# Altium schematic sheet CUBETTO ALM.SchDoc("CUBETTO ALM")
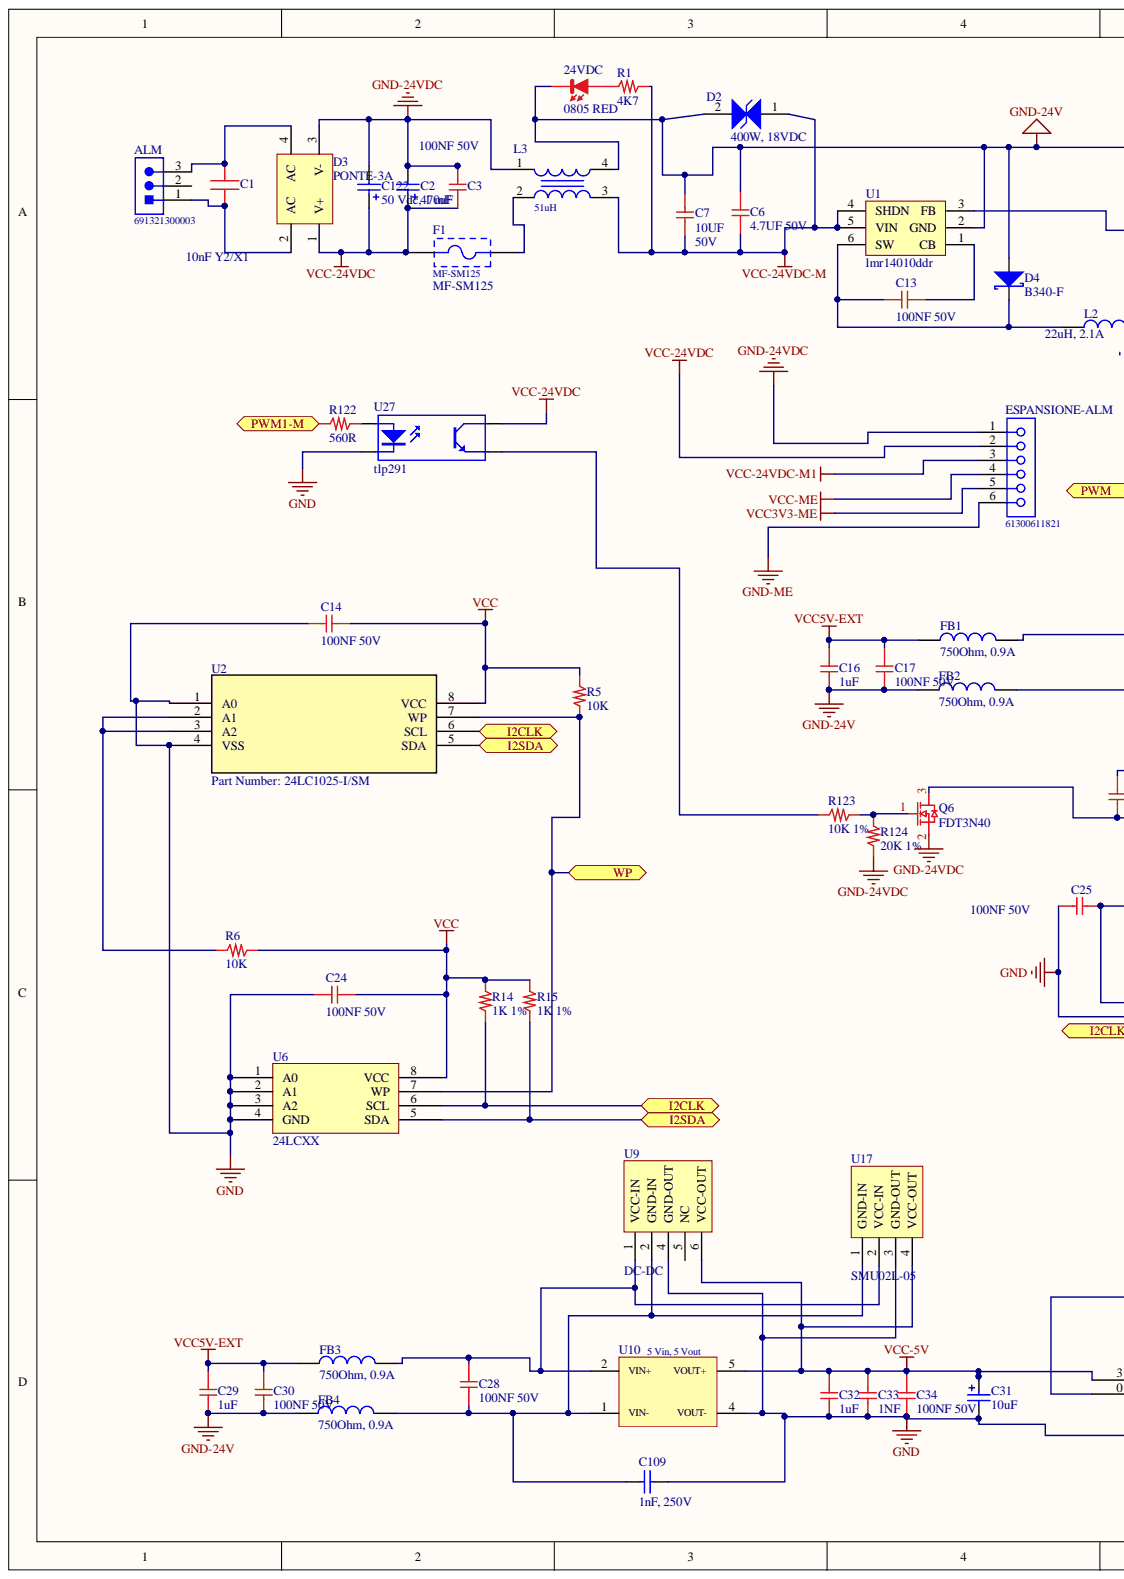
[diagram p0: CUBETTO ALM.SchDoc, left]
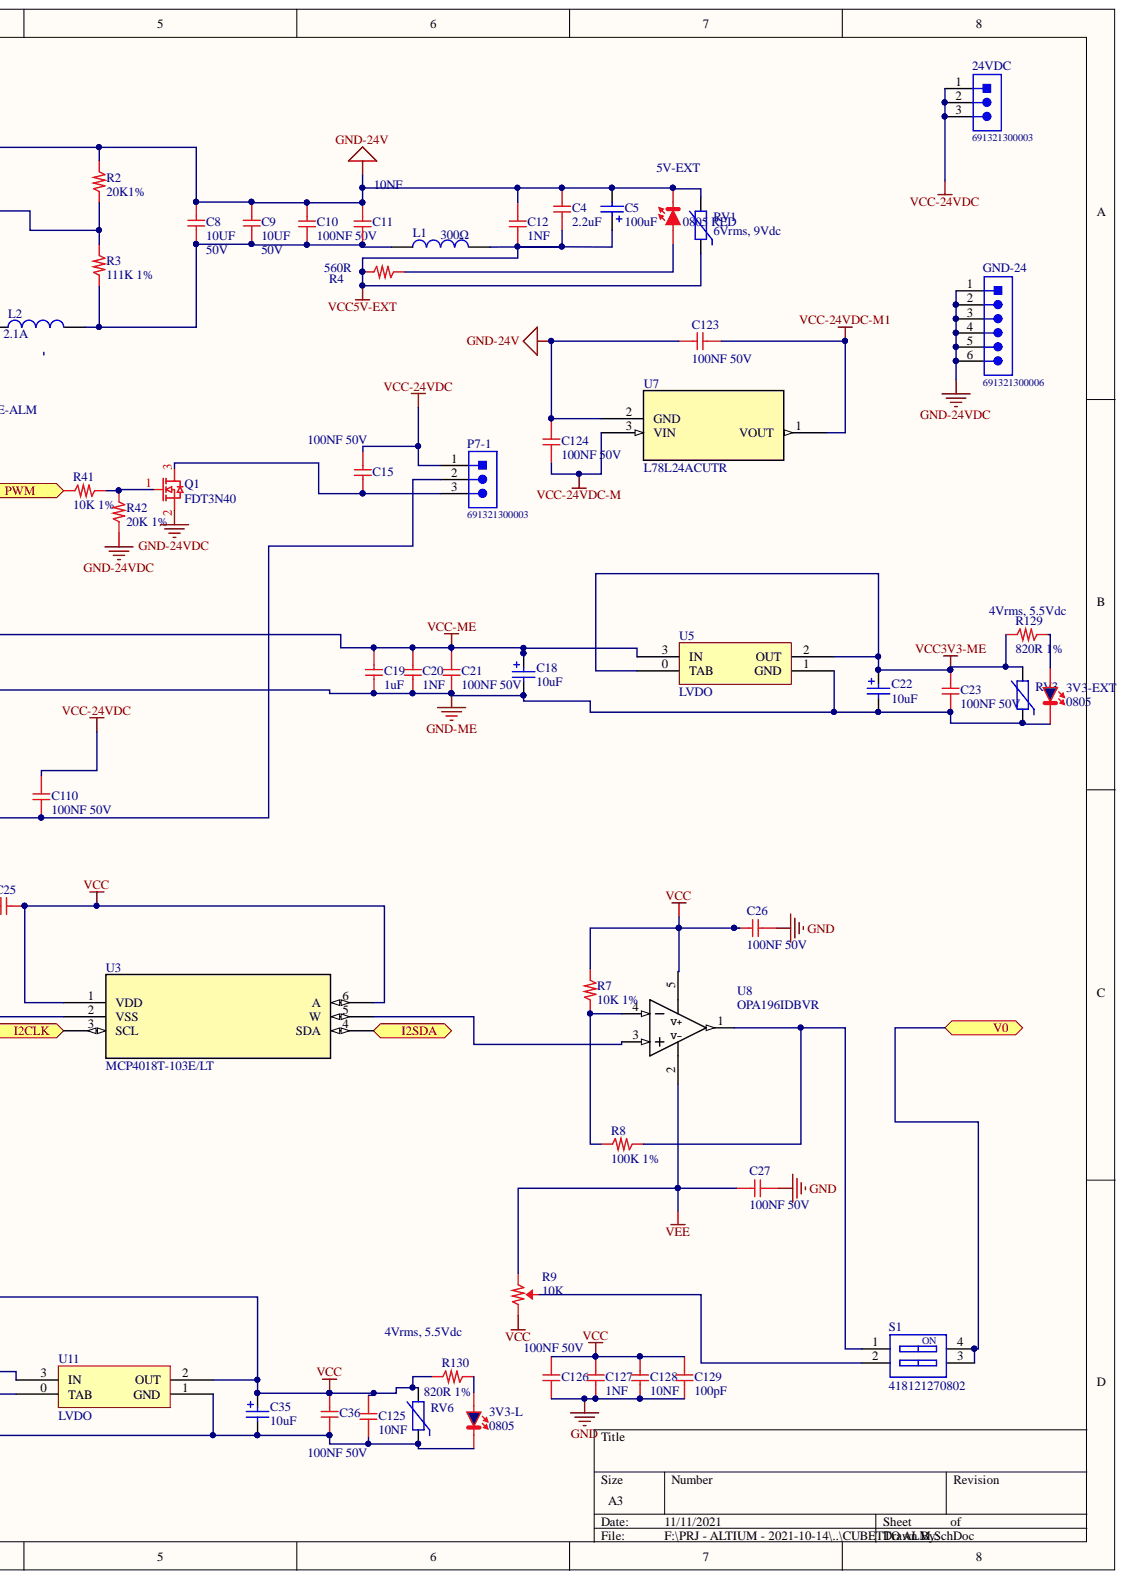
[diagram p1: CUBETTO ALM.SchDoc, right]

## Parts
3V3-EXT  0805  [0805-BLU]
3V3-L  0805  [0805-BLU]
5V-EXT  0805 RED  [0805_Red]
24VDC  0805 RED  [0805_Red]
24VDC  691321300003  [691321300003]
ALM  691321300003  [691321300003]
C1  10nF Y2/X1  [2220_C]
C2  value 470uF  [WCAP-ASLL_16x17]  (hidden comment: 865060663013)
C3  100NF 50V  [0603_c]
C4  2.2uF  [0603_c]
C5  value 100uF  [WCAP-ASLL_6.3x5.5]  (hidden comment: 865060143004)
C6  4.7UF 50V  [0805_C]
C7  10UF  Tensione: 50V  [1206_C]
C8  10UF  Tensione: 50V  [1206_C]
C9  10UF  Tensione: 50V  [1206_C]
C10  100NF 50V  [0603_c]
C11  10NF  [0603_c]
C12  1NF  [0603_c]
C13  100NF 50V  [0603_c]
C14  100NF 50V  [0603_c]
C15  100NF 50V  [0603_c]
C16  1uF  [0603_c]
C17  100NF 50V  [0603_c]
C18  value 10uF  [WCAP-ASLL_4x5.5]  (hidden comment: 865060340001)
C19  1uF  [0603_c]
C20  1NF  [0603_c]
C21  100NF 50V  [0603_c]
C22  value 10uF  [WCAP-ASLL_4x5.5]  (hidden comment: 865060340001)
C23  100NF 50V  [0603_c]
C24  100NF 50V  [0603_c]
C25  100NF 50V  [0603_c]
C26  100NF 50V  [0603_c]
C27  100NF 50V  [0603_c]
C28  100NF 50V  [0603_c]
C29  1uF  [0603_c]
C30  100NF 50V  [0603_c]
C31  value 10uF  [WCAP-ASLL_4x5.5]  (hidden comment: 865060340001)
C32  1uF  [0603_c]
C33  1NF  [0603_c]
C34  100NF 50V  [0603_c]
C35  value 10uF  [WCAP-ASLL_4x5.5]  (hidden comment: 865060340001)
C36  100NF 50V  [0603_c]
C109  1nF, 250V  [WCAP-CSSA_1808_H=2mm]
C110  100NF 50V  [0603_c]
C122  50 Vdc, 1 mF  value 1 mF  [WCAP-ATLI_16x25x7.5]
C123  100NF 50V  [0603_c]
C124  100NF 50V  [0603_c]
C125  10NF  [0603_c]
C126  100NF 50V  [0603_c]
C127  1NF  [0603_c]
C128  10NF  [0603_c]
C129  100pF  [0603_c]
D2  400W, 18VDC  [WE-TVSP_DO-214AC_Bidirectional]
D3  PONTE-3A  [RDBF-310]
D4  B340-F  [SMA]
ESPANSIONE-ALM  61300611821  [61300611821]
F1  MF-SM125  [FUSESMD]
FB1  750Ohm, 0.9A  Rated Current: 0.9A  [WE-CBF_0603_Wide Band]
FB2  750Ohm, 0.9A  Rated Current: 0.9A  [WE-CBF_0603_Wide Band]
FB3  750Ohm, 0.9A  Rated Current: 0.9A  [WE-CBF_0603_Wide Band]
FB4  750Ohm, 0.9A  Rated Current: 0.9A  [WE-CBF_0603_Wide Band]
GND-24  691321300006  [691321300006]
L1  300Ω  [WE-CBF_0805_High Current]
L2  22uH, 2.1A  Rated Current: 2.1A  [WE-LQS_8040]
L3  51uH  [WE-SL2]
P7-1  691321300003  [691321300003]
Q1  FDT3N40  [FDT3N40]
Q6  FDT3N40  [FDT3N40]
R1  4K7  [1206_R]
R2  20K1%  [0603_R]
R3  111K 1%  [0603_R]
R4  560R  [0603_R]
R5  10K  [0603_R]
R6  10K  [0603_R]
R7  10K 1%  [0603_R]
R8  100K 1%  [0603_R]
R9  10K  [ResTrimSuntan5X10X10]
R14  1K 1%  [0603_R]
R15  1K 1%  [0603_R]
R41  10K 1%  [0603_R]
R42  20K 1%  [0603_R]
R122  560R  [0603_R]
R123  10K 1%  [0603_R]
R124  20K 1%  [0603_R]
R129  820R 1%  [0603_R]
R130  820R 1%  [0603_R]
RV1  6Vrms, 9Vdc  Size: 0805  [WE-VS_0805]
RV3  4Vrms, 5.5Vdc  [WE-VS_0603]
RV6  4Vrms, 5.5Vdc  [WE-VS_0603]
S1  418121270802  [418121270802]
U1  lmr14010ddr  [SOT23-6_N]
U2  Part Number: 24LC1025-I/SM  [SOIJ8-M_MC]
U3  MCP4018T-103E/LT  [SOT363]
U5  LVDO  [SOT-223]
U6  24LCXX  [SO8_N]
U7  L78L24ACUTR  [SOT-89-3]
U8  OPA196IDBVR  [DBV0005A_N]
U9  DC-DC  [DC-DC-DL 2W]
U10  5 Vin, 5 Vout  [MagI³C-FISM_SMT-8]
U11  LVDO  [SOT-223]
U17  SMU02L-05  [DC.DC-2W]
U27  tlp291  [SO4_N]

## Ports
I2CLK, I2SDA, PWM, PWM1-M, V0, WP

## Nets
GND: 3V3-L.K C14.1 C24.1 C25.1 C26.1 C27.1 C31.2 C32.2 C33.2 C34.2 C35.2 C36.2 C109.2 C125.2 C126.2 C127.2 C128.2 C129.2 RV6.1 U2.1(A0) U2.4(VSS) U3.2(VSS) U6.1(A0) U6.2(A1) U6.3(A2) U6.4(GND) U9.4(GND-OUT) U10.4(VOUT-) U11.1(GND) U17.3(GND-OUT) U27.2
GND-24V: 5V-EXT.K 24VDC.K C4.1 C5.2 C6.2 C7.1 C8.2 C9.2 C10.2 C11.2 C12.1 C16.2 C17.2 C29.2 C30.2 C123.2 C124.2 D2.2 D4.1 FB2.1 FB4.1 L3.4 R2.1 RV1.2 U1.2(GND) U7.2
GND-24VDC: C2.2 C3.2 C122.2 D3.3(V-) ESPANSIONE-ALM.1 GND-24.1 GND-24.2 GND-24.3 GND-24.4 GND-24.5 GND-24.6 L3.1 Q1.2 Q6.2 R42.2 R124.2
GND-ME: 3V3-EXT.K C18.2 C19.2 C20.2 C21.2 C22.2 C23.2 ESPANSIONE-ALM.6 FB2.2 RV3.1 U5.1(GND)
VCC: C14.2 C24.2 C25.2 C26.2 C35.1 C36.1 C125.1 C126.1 C127.1 C128.1 C129.1 R5.1 R6.2 R7.1 R9.3 R14.2 R15.1 R130.1 RV6.2 U2.8(VCC) U3.1(VDD) U3.6(A) U6.8(VCC) U8.5(V+) U11.0(TAB) U11.2(OUT)
VCC3V3-ME: C22.1 C23.1 ESPANSIONE-ALM.5 R129.1 RV3.2 U5.0(TAB) U5.2(OUT)
VCC5V-EXT: C4.2 C5.1 C12.2 C16.1 C17.1 C29.1 C30.1 FB1.1 FB3.1 L1.2 R4.1 RV1.1
VCC-5V: C31.1 C32.1 C33.1 C34.1 U9.6(VCC-OUT) U10.5(VOUT+) U11.3(IN) U17.4(VCC-OUT)
VCC-24VDC: 24VDC.1 24VDC.2 24VDC.3 C2.1 C3.1 C15.2 C110.2 C122.1 D3.1(V+) ESPANSIONE-ALM.2 F1.2 P7-1.1 U27.4
VCC-24VDC-M: C6.1 C7.2 C124.1 D2.1 L3.3 R1.2 U1.4(SHDN) U1.5(VIN) U7.3
VCC-24VDC-M1: C123.1 ESPANSIONE-ALM.3 U7.1
VCC-ME: C18.1 C19.1 C20.1 C21.1 ESPANSIONE-ALM.4 FB1.2 U5.3(IN)
VEE: C27.2 R9.1 U8.2(V-)
I2CLK: R14.1 U2.6(SCL) U3.3(SCL) U6.6(SCL) ; port I2CLK
I2SDA: R15.2 U2.5(SDA) U3.4(SDA) U6.5(SDA) ; port I2SDA
PWM: R41.1 ; port PWM
PWM1-M: R122.1 ; port PWM1-M
V0: S1.3 S1.4 ; port V0
WP: R5.2 U2.7(WP) U6.7(WP) ; port WP
Net3V3-EXT_A: 3V3-EXT.A R129.2
Net3V3-L_A: 3V3-L.A R130.2
Net5V-EXT_A: 5V-EXT.A R4.2
Net24VDC_A: 24VDC.A R1.1
NetALM_1: ALM.1 C1.2 D3.2(AC)
NetALM_3: ALM.3 C1.1 D3.4(AC)
NetC8_1: C8.1 C9.1 C10.1 C11.1 L1.1 L2.2 R3.2
NetC13_1: C13.1 D4.2 L2.1 U1.6(SW)
NetC13_2: C13.2 U1.1(CB)
NetC15_1: C15.1 P7-1.3 Q1.3
NetC28_1: C28.1 FB3.2 U9.1(VCC-IN) U10.2(VIN+) U17.2(VCC-IN)
NetC28_2: C28.2 C109.1 FB4.2 U9.2(GND-IN) U10.1(VIN-) U17.1(GND-IN)
NetC110_1: C110.1 P7-1.2 Q6.3
NetF1_1: F1.1 L3.2
NetQ1_1: Q1.1 R41.2 R42.1
NetQ6_1: Q6.1 R123.2 R124.1
NetR2_2: R2.2 R3.1 U1.3(FB)
NetR6_1: R6.1 U2.2(A1) U2.3(A2)
NetR7_2: R7.2 R8.1 U8.4
NetR8_2: R8.2 S1.1 U8.1
NetR9_2: R9.2 S1.2
NetR122_2: R122.2 U27.1
NetR123_1: R123.1 U27.3
NetU3_5: U3.5(W) U8.3
N00415:

Not connected: ALM 2; U9 5(NC)

## Library data of the parts
3V3-EXT 3V3-L = LED BLU 0805: Vf(typ): 3.3V; Produttore: kingbright; Luminosità: 100mcd 20mA; Cod. Produttore: KPTR-3216QBC-D; Description: LED BLU 0805; Component Kind: Standard; Library Name: DiodiLED.SchLib
5V-EXT 24VDC = LED_ROSSO_0805: LED Rosso 0805; V: 2.0V; Produttore: WE; Luminosità: 150mcd; Cod. Produttore: 150080RS75000; Cod. Interno: LEDS 2AC080RS7500; Component Kind: Standard; Library Name: DiodiLED.SchLib
24VDC ALM P7-1 = 691321300003: Serie 321 - 3.81mm Vertical PCB Header WR-TBL, 3 pin; Pin Number: 3; Gender: Male; Published Date: June 2018; Pitch: 3.81 mm; Part Number: 691321300003; Packaging: Box; Operating Temperature: -40 to 105 degC; Mounting Technology: THT; Library Name: Pluggable PCB System WR-TBL_3.81 mm.SchLib; ComponentLink2URL: http://katalog.we-online.de/em/datasheet/6913213000xx.pdf; ComponentLink2Description: Datasheet; ComponentLink1URL: http://www.we-online.de; ComponentLink1Description: Manufacturer; Component Kind: Standard; Publisher: Wurth Elektronik
C1 = 10nF Y2/X1: Cap X7R 250Vac 2220 10nF; Produttore: Syfer Technology; Cod. RS: 773-9840; Cod. Produttore: 2220YA250103JXTB17; Cod. Interno: COCS??; Component Kind: Standard; Library Name: Varie.SchLib
C2 = 865060663013: WCAP-ASLL Aluminum Electrolytic Capacitors, size Ф16x17mm, 50V DC, 470uF; Rated Voltage: 50V DC; Size: Ф16x17mm; Published Date: June 2017; Part Number: 865060663013; Operating Temperature: -55 °C up to +105 °C; Life Cycle: 5000h; Library Name: WCAP-ASLL.SchLib; ComponentLink2URL: http://katalog.we-online.de/pbs/datasheet/865060663013.pdf; ComponentLink2Description: Datasheet; ComponentLink1URL: http://www.we-online.de; ComponentLink1Description: Manufacturer; Component Kind: Standard; Publisher: Wurth Elektronik
C3 C10 C13-C15 C17 C21 C23-C28 C30 C34 C36 C110 C123 C124 C126 = 100NF 50V: Cap X7R 100nF 50V Flex Terminal; Produttore: Samsung; Cod. Produttore: CL10B104KB8SFNC; Cod. Interno: COCS50665050104; Library Name: CapSMD0603.SchLib; Component Kind: Standard; Cod. DigiKey: 1276-1936-2-ND
C4 = 2.2uF 10V: 2.2 µF, 10 V, ± 10%, X5R 0603; Produttore: WALSIN; Costo 1000: 0,0183; Costo 100: 0,0436; Cod. Produttore: 0603X225K100CT; Cod. Interno: COCS??; Library Name: CapSMD0603.SchLib; ComponentLink1URL: http://it.farnell.com/walsin/0603x225k100ct/cond-mlcc-x5r-2-2uf-10v-0603-bob/dp/2495422?st=2.2uf; ComponentLink1Description: FARNELL; Component Kind: Standard
C5 = 865060143004: WCAP-ASLL Aluminum Electrolytic Capacitors, size Ф6.3x5.5mm, 6.3V DC, 100uF; Rated Voltage: 6.3V DC; Size: Ф6.3x5.5mm; Published Date: June 2017; Part Number: 865060143004; Operating Temperature: -55 °C up to +105 °C; Life Cycle: 2000h; Library Name: WCAP-ASLL.SchLib; ComponentLink2URL: http://katalog.we-online.de/pbs/datasheet/865060143004.pdf; ComponentLink2Description: Datasheet; ComponentLink1URL: http://www.we-online.de; ComponentLink1Description: Manufacturer; Component Kind: Standard; Publisher: Wurth Elektronik
C6 = 4.7UF 50V: Cap X5R 4.7UF 50V; Produttore: TDK; Cod. Produttore: C2012X5R1H475K; Cod. Interno: COCS517065000475; Temperature Range: Da -55°C a +105°C; Library Name: CapSMD0805.SchLib; Component Kind: Standard
C7-C9 = 10UF 50V: Cap X5R 10UF 50V; Produttore: MURATA; Cod. Produttore: GRM31CR61H106KA12L; Temperature Range: Da -55°C a +125°C; Library Name: CapSMD1206.SchLib; Component Kind: Standard; Cod. Farnell: 2666583
C11 C125 C128 = 10NF 50V: Cap X7R 10nF 50V; Produttore: Samsung; Cod. Produttore: CL05B103KB5NNNC; Cod. Interno: COCS 556655000103; Library Name: CapSMD0603.SchLib; Component Kind: Standard; Cod. DigiKey: 1276-1028-2-ND
C12 C20 C33 C127 = 1NF 50V: Cap X7R 1nF 50V; Cod. Interno: COCS 50665050102; Library Name: CapSMD0603.SchLib; Component Kind: Standard
C16 C19 C29 C32 = 1uF 10V: 2.2 µF, 10 V, ± 10%, X5R 0603; Produttore: WALSIN; Costo 1000: 0,0183; Costo 100: 0,0436; Cod. Produttore: 0603X225K100CT; Cod. Interno: COCS??; Library Name: CapSMD0603.SchLib; ComponentLink1URL: http://it.farnell.com/walsin/0603x225k100ct/cond-mlcc-x5r-2-2uf-10v-0603-bob/dp/2495422?st=2.2uf; ComponentLink1Description: FARNELL; Component Kind: Standard
C18 C22 C31 C35 = 865060340001: WCAP-ASLL Aluminum Electrolytic Capacitors, size Ф4x5.5mm, 16V DC, 10uF; Rated Voltage: 16V DC; Size: Ф4x5.5mm; Published Date: June 2017; Part Number: 865060340001; Operating Temperature: -55 °C up to +105 °C; Life Cycle: 2000h; Library Name: WCAP-ASLL.SchLib; ComponentLink2URL: http://katalog.we-online.de/pbs/datasheet/865060340001.pdf; ComponentLink2Description: Datasheet; ComponentLink1URL: http://www.we-online.de; ComponentLink1Description: Manufacturer; Component Kind: Standard; Publisher: Wurth Elektronik
C109 = 885362210017: WCAP-CSSA X&Y Safety Ceramic Capacitors, size 1808, 1nF, 250VAC; Technical Reference: X7R1808102KB50IFCT10000; Safety Class: X2; Isolation Resistance: 10GOhm; Dissipation Factor: 2.5%; Ceramic Type: X7R; Size: 1808; Rated Voltage: 250VAC; Published Date: August 2019; Part Number: 885362210017; Packaging: Tape and Reel; Operating Temperature: -55 to +125degC; Mounting Technology: SMD; Library Name: WCAP-CSSA_1808.SchLib; Height: 2mm +/-0.2mm; ComponentLink2URL: https://katalog.we-online.com/pbs/datasheet/885362210017.pdf; ComponentLink2Description: Datasheet; ComponentLink1URL: http://www.we-online.de; ComponentLink1Description: Manufacturer; Component Kind: Standard; Capacitance: 1nF; Publisher: Wurth Elektronik
C122 = 860080680024: WCAP-ATLI Aluminum Electrolytic Capacitors, 16x25mm, 50 Vdc, 1 mF; Value: 1 mF; Rated Voltage: 50 Vdc; Size: 16x25mm; Published Date: Sep. 2017; Part Number: 860080680024; Operating Temperature: -55 °C up to +105 °C; Life Cycle: 5000h; Library Name: WCAP-ATLI.SchLib; ComponentLink2URL: http://katalog.we-online.com/pbs/datasheet/860080680024.pdf; ComponentLink2Description: Datasheet; ComponentLink1URL: http://www.we-online.de; ComponentLink1Description: Manufacturer; Component Kind: Standard; Publisher: Wurth Elektronik
C129 = 100pF 50V: Cap X7R 100pF 50V; Produttore: ??; Cod. Interno: COCS??; Library Name: CapSMD0603.SchLib; Component Kind: Standard; Cod. Produttore: ??
D2 = 824501181: WE-TVSP Power TVS Diode, DO-214AC, 400W, 18VDC, Bidirectional; DC Operating Voltage: 18V; Clamping Voltage: 29.2V; (Reverse) Breakdown Voltage: 21.05V; (Forward) Peak Pulse Current: 13.7A; Steady State Power Dissipation: 400W; Size: DO-214AC; Published Date: March 2019; Polarity: Bidirectional; Part Number: 824501181; Packaging: Tape and Reel; Operating Temperature: -65 to +150degC; Mounting Technology: SMT; Library Name: WE-TVSP_DO-214AC_Bidirectional.SchLib; ComponentLink2URL: https://katalog.we-online.com/pbs/datasheet/824501181.pdf; ComponentLink2Description: Datasheet; ComponentLink1URL: http://www.we-online.de; ComponentLink1Description: Manufacturer; Component Kind: Standard; Publisher: Wurth Elektronik
D3 = RDBF-310: Diode Bridge 3A SMD; Simulation: BRIDGE; Published: 24-Mar-1999; PackageReference: D-46_6A; PackageDescription: Bridge Rectifier; 4 Terminals; Body 16.8 x 16.8 mm (typ); Pitch 10.67 mm; LatestRevisionNote: Re-released for DXP Platform.; LatestRevisionDate: 17-Jul-2002; Component Kind: Standard; Publisher: Altium Limited; Library Name: HSW.SchLib
D4 = B340-F: Schottky Diode; Simulation: SKYDIODE; Signal Integrity: D Schottky; Published: 8-Jun-2000; PackageReference: SMB; PackageDescription: DO-214-AA/SMB; 2 C-Bend Leads; Body 5.3 x 3.6 mm, inc. leads (LxW); LatestRevisionNote: Re-released for DXP Platform.; LatestRevisionDate: 17-Jul-2002; Component Kind: Standard; Code_JEDEC: DO-214-AA; Publisher: Altium Limited; Library Name: HSW.SchLib
ESPANSIONE-ALM = 61300611821: WR-PHD Socket Header, THT, pitch 2.54mm, Single Row, Vertical, 6p; Manufacturer: Wurth Elektronik; Working Voltage: 250VAC; Withstanding Voltage: 500VAC; Rated Current: 3A; Pin Number: 6; Contact Resistance: 20mOhm; Row Number: Single; Published Date: May 2020; Pitch: 2.54mm; Packaging: Tray; Orientation: Vertical; Operating Temperature: -40 to +125degC; Mounting Technology: THT; Manufacturer Part Number: 61300611821; Library Name: WR-PHD_Socket Header_2.54mm.SchLib; Gender: Socket Header; ComponentLink2URL: https://www.we-online.com/catalog/datasheet/61300611821.pdf; ComponentLink2Description: Datasheet; ComponentLink1URL: http://www.we-online.de; ComponentLink1Description: Manufacturer; Component Kind: Standard
F1 = MF-SM125: PPTC 1,25 260VAC; Part Number: 696108003002; Published Date: August 2018; Packaging: Box; Operating Temperature: -30 to 120 degC; Mounting Technology: THT; Fuse Size: MF-SM125; ComponentLink2URL: https://katalog.we-online.de/em/datasheet/696108003002.pdf; ComponentLink2Description: Datasheet; ComponentLink1URL: http://www.we-online.de; ComponentLink1Description: Manufacturer; Component Kind: Standard; Publisher: Wurth Elektronik; Library Name: HSW.SchLib
FB1-FB4 = 742792656: WE-CBF SMT EMI Suppression Ferrite Bead, size 0603, 750Ohm, 0.9A; Type: Wide Band; Impedance max.: 800Ohm; DC Resistance max.: 0.35Ohm; Size: 0603; Published Date: March 2019; Part Number: 742792656; Packaging: Tape and Reel; Operating Temperature: -55 to +125degC; Mounting Technology: SMT; Library Name: WE-CBF_0603.SchLib; Impedance@100 MHz: 750Ohm; ComponentLink2URL: https://katalog.we-online.com/pbs/datasheet/742792656.pdf; ComponentLink2Description: Datasheet; ComponentLink1URL: http://www.we-online.de; ComponentLink1Description: Manufacturer; Component Kind: Standard; Publisher: Wurth Elektronik
GND-24 = 691321300006: Serie 321 - 3.81mm Vertical PCB Header WR-TBL, 6 pin; Pin Number: 6; Gender: Male; Published Date: June 2018; Pitch: 3.81 mm; Part Number: 691321300006; Packaging: Box; Operating Temperature: -40 to 105 degC; Mounting Technology: THT; Library Name: Pluggable PCB System WR-TBL_3.81 mm.SchLib; ComponentLink2URL: http://katalog.we-online.de/em/datasheet/6913213000xx.pdf; ComponentLink2Description: Datasheet; ComponentLink1URL: http://www.we-online.de; ComponentLink1Description: Manufacturer; Component Kind: Standard; Publisher: Wurth Elektronik
L1 = WE-CBF, 742792031: WE-CBF SMD EMI Suppression Ferrite Bead, 0805, 300Ω; Type: High Current; Value: 300Ω; Size: 0805; PublishedDate: Aug 2016; PartNumber: 742792031; Library Name: WE-CBF_0805.SchLib; ComponentLink2URL: http://katalog.we-online.de/pbs/datasheet/742792031.pdf; ComponentLink2Description: Datasheet; ComponentLink1URL: http://www.we-online.de; ComponentLink1Description: Manufacturer; Component Kind: Standard; Publisher: Wurth Elektronik
L2 = 74404084220: WE-LQS SMD Power Inductor, size 8040, 22uH, 2.1A; Self Resonant Frequency: 15MHz; Saturation Current: 2.6A; Inductance Tolerance: +/-20%; DC Resistance Tolerance: +/-30%; DC Resistance: 69mOhm; Size: 8040; Published Date: May 2019; Part Number: 74404084220; Packaging: Tape and Reel; Operating Temperature: -40 to +125degC; Mounting Technology: SMT; Library Name: WE-LQS_8040.SchLib; Inductance: 22uH; ComponentLink2URL: https://katalog.we-online.com/pbs/datasheet/74404084220.pdf; ComponentLink2Description: Datasheet; ComponentLink1URL: http://www.we-online.de; ComponentLink1Description: Manufacturer; Component Kind: Standard; Publisher: Wurth Elektronik
L3 = 744227S: WE-SL2 SMT Common Mode Line Filter, 51uH, 1000mA, 80V; Winding Style: sectional; Leakage Inductance: 3150nH; Insulation Test Voltage: 500V (AC); Impedance max.: 5500Ohm; DC Resistance max.: 0.16Ohm; Rated Voltage: 80V; Rated Current: 1000mA; Published Date: March 2019; Part Number: 744227S; Packaging: Tape and Reel; Operating Temperature: -40 to +125degC; Mounting Technology: SMT; Library Name: WE-SL2.SchLib; Inductance: 51uH; ComponentLink2URL: https://katalog.we-online.com/pbs/datasheet/744227S.pdf; ComponentLink2Description: Datasheet; ComponentLink1URL: http://www.we-online.de; ComponentLink1Description: Manufacturer; Component Kind: Standard; Publisher: Wurth Elektronik
Q1 Q6 = FDT3N40: N-Channel 20Vds 3Aid; Produttore: CT-Micro; Cod. Produttore: CT2300-R3; Component Kind: Standard; Library Name: HSW.SchLib
R1 = 4K7: 4K7 1206 1/4W; Library Name: ResSMD1206.SchLib; Component Kind: Standard; Case: 1206
R2 R3 = 110K 1%: Res Film Spesso 1/16W 110K 1%; Library Name: ResSMD0603.SchLib; Component Kind: Standard
R4 R122 = 560R: Res Film Spesso 1/16W 560R 5%; Cod. Interno: RESS 4166556B0561; Library Name: ResSMD0603.SchLib; Component Kind: Standard
R5 R6 = 10K: Res Film Spesso 1/16W 10K 5%; Produttore: ??; Cod. Interno: RESS4166556B0103??; Library Name: ResSMD0603.SchLib; Component Kind: Standard; Cod. Produttore: ??
R7 R41 R123 = 10K 1%: Res Film Spesso 1/16W 10K 1%; Produttore: Panasonic; Cod. Produttore: ERJ-3EKF1002V; Cod. Interno: RESS4166356B0103; Library Name: ResSMD0603.SchLib; Component Kind: Standard
R8 = 100K 1%: Res Film Spesso 1/16W 100K 1%; Produttore: ??; Cod. Interno: RESS4166360C0104; Library Name: ResSMD0603.SchLib; Component Kind: Standard; Cod. Produttore: ??
R9 = 10K POT.V: Multiturn Trimmer; Produttore: Suntan; Component Kind: Standard; Cod. Produttore: TSR-3296; Library Name: ResTrimPTH.SchLib
R14 R15 = 1K 1%: Res Film Spesso 1/16W 1K 1%; Produttore: ??; Cod. Interno: RESS4166356B0102; Library Name: ResSMD0603.SchLib; Component Kind: Standard; Cod. Produttore: ??
R42 R124 = 20K 1%: Res Film Spesso 1/16W 20K 1%; Cod. Interno: RESS 4166360C0203; Library Name: ResSMD0603.SchLib; Component Kind: Standard
R129 R130 = 820R 1%: Res Film Spesso 1/16W 820R 1%; Produttore: ??; Cod. Interno: RESS4166356B0681; Library Name: ResSMD0603.SchLib; Component Kind: Standard; Cod. Produttore: ??
RV1 = 82550060: WE-VS SMD Varistor, size 0805, 6Vrms, 9Vdc; Withstanding Surge Current: 80A; Varistor Voltage: 12V; Energy Dissipation: 0.1J; Clamping Voltage: 20V; Published Date: March 2019; Part Number: 82550060; Packaging: Tape and Reel; Operating Temperature: -40 to +85degC; Mounting Technology: SMT; Library Name: WE-VS_0805.SchLib; DC Operating Voltage: 9Vdc; ComponentLink2URL: https://katalog.we-online.com/pbs/datasheet/82550060.pdf; ComponentLink2Description: Datasheet; ComponentLink1URL: http://www.we-online.de; ComponentLink1Description: Manufacturer; Component Kind: Standard; AC Operating Voltage: 6Vrms; Publisher: Wurth Elektronik
RV3 RV6 = 82536040: WE-VS SMD Varistor, size 0603, 4Vrms, 5.5Vdc; Withstanding Surge Current: 30A; Varistor Voltage: 8V; Energy Dissipation: 0.1J; Clamping Voltage: 21V; Size: 0603; Published Date: March 2019; Part Number: 82536040; Packaging: Tape and Reel; Operating Temperature: -40 to +85degC; Mounting Technology: SMT; Library Name: WE-VS_0603.SchLib; DC Operating Voltage: 5.5Vdc; ComponentLink2URL: https://katalog.we-online.com/pbs/datasheet/82536040.pdf; ComponentLink2Description: Datasheet; ComponentLink1URL: http://www.we-online.de; ComponentLink1Description: Manufacturer; Component Kind: Standard; AC Operating Voltage: 4Vrms; Publisher: Wurth Elektronik
S1 = 418121270802: SMD Dip Switch WS-DISV, Small Compact, Raised Actuator, pitch 2.54mm, 2pin; Pole Number: 2; Operation Force: 600g max.; Actuator Type: Raise Type; Published Date: April 2019; Pitch: 2.54mm; Part Number: 418121270802; Packaging: Tape and Reel; Operating Temperature: -40 to +85degC; Mounting Technology: SMT; ComponentLink2URL: https://katalog.we-online.com/em/datasheet/418121270802.pdf; ComponentLink2Description: Datasheet; ComponentLink1URL: http://www.we-online.de; ComponentLink1Description: Manufacturer; Component Kind: Standard; Publisher: Wurth Elektronik; Library Name: DIPSMD WS-DISV.SchLib
U2 = 24LC1025-I/SM: No Description Available; Vendor: Microchip; Built_By: EMA_UL_Team; Value: Value; Type: DEV; RefDes: RefDes; Manufacturer_Part_Number: 24LC1025-I/SM; Library Name: 24LC1025.SchLib; Component Kind: Standard; Copyright: Copyright (C) 2018 Accelerated Designs. All rights reserved
U3 = MCP4018T-103E/LT: Imported; Vendor: Microchip; C: Copyright (C) 2015 Accelerated Designs. All rights reserved; Built_By: EMA_UL_Team; Value: Value; Type: DEV; RefDes: RefDes; Manufacturer_Part_Number: MCP4018T-103E/LT; Component Kind: Standard; Library Name: HSW.SchLib
U5 U11 = LD1117S33TR: REGOLATORE IN; Component Kind: Standard; Library Name: HSW.SchLib
U6 = 24LCXX: 24LCXX EEPROM; Component Kind: Standard; Library Name: HSW.SchLib
U7 = L78L24ACUTR: REGOLATORE DI TENSIONE 24VDC; Vendor: STMicroelectronics; SourceLibrary: StMicro_2011-06-07; Built_By: EMA_UL_Team; Type: DEV; RefDes: RefDes; Manufacturer_Part_Number: L78L24ACUTR; Component Kind: Standard; Library Name: HSW.SchLib; Copyright: Copyright (C) 2018 Accelerated Designs. All rights reserved
U8 = OPA196IDBVR: No Description Available; Manufacturer: Texas Instruments; Value: Value; Type: DEV; RefDes: RefDes; PartNumber: OPA196IDBVR; Component Kind: Standard; Library Name: HSW.SchLib; Copyright: Copyright (C) 2018 Accelerated Designs. All rights reserved
U9 = DC-DC-2W-DL: Description: DC-DC-2W-DL; Component Kind: Standard; Library Name: HSW.SchLib
U10 = 176920512: MagI³C-FISM Fixed Isolated Module, SMT-8, 5 Vin, 5 Vout; Output Voltage: 5 V; Output Power: 1 W; Size: SMT-8; Published Date: August 2018; Part Number: 176920512; Packaging: Tape and Reel; Operating Temperature: -40 to 100 degC; Mounting Technology: SMT; Library Name: MagI³C-FISM_SMT-8.SchLib; Input Voltage: 5 V; ComponentLink2URL: https://katalog.we-online.de/pm/datasheet/176920512.pdf; ComponentLink2Description: Datasheet; ComponentLink1URL: http://www.we-online.de; ComponentLink1Description: Manufacturer; Component Kind: Standard; Publisher: Wurth Elektronik
U17 = DC-DC-2W: DC-DC-2W  MEANWELL SMU02L-05; Component Kind: Standard; Library Name: HSW.SchLib
U27 = tlp291: 4-Pin Phototransistor Optocoupler; Published: 24-Mar-1999; PackageReference: DIP-4; LatestRevisionNote: Sch pins 3 & 4 Swapped.; LatestRevisionDate: 04-Mar-2005; DatasheetVersion: Nov-2003; Component Kind: Standard; Publisher: Altium Limited; Library Name: HSW.SchLib
U1 = lmr14010ddr: Component Kind: Standard; Library Name: HSW.SchLib
